# Altium schematic sheet Control_Wi-Fi_Module.SchDoc(Control_Wi-Fi_Module)
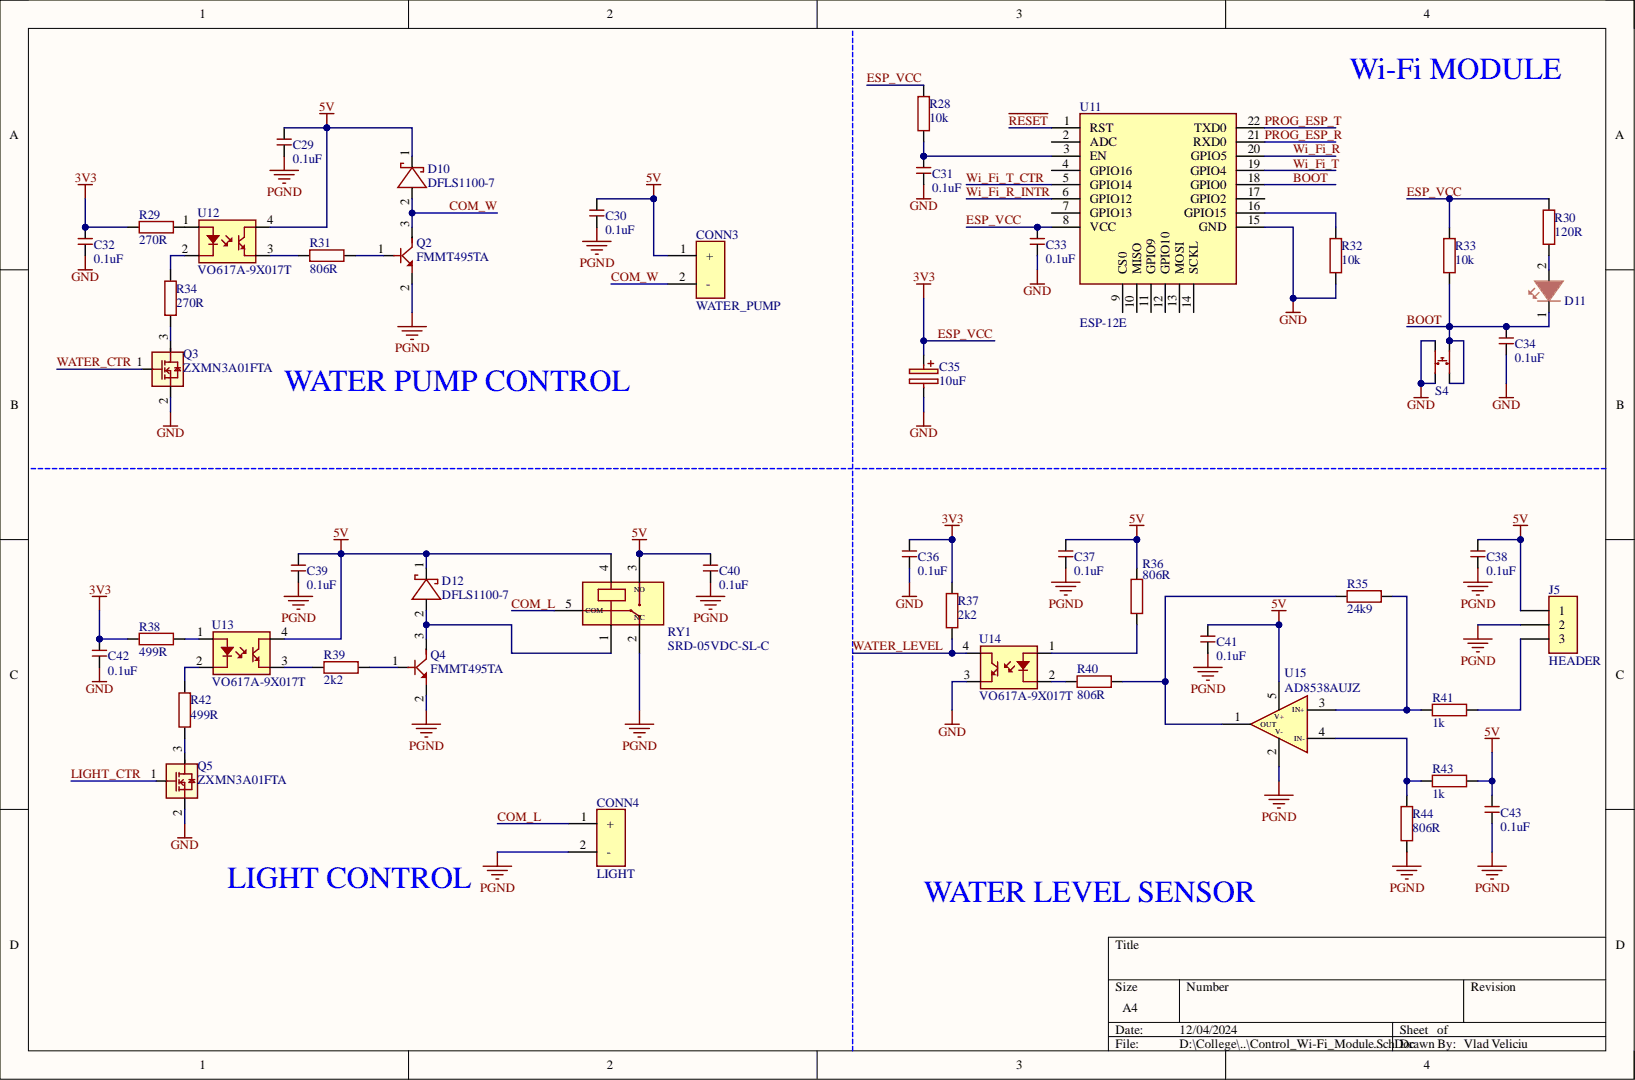
[diagram p0: Control_Wi-Fi_Module.SchDoc]

## Parts
C29  0.1uF  [C0603]
C30  0.1uF  [C0603]
C31  0.1uF  [C0603]
C32  0.1uF  [C0603]
C33  0.1uF  [C0603]
C34  0.1uF  [C0603]
C35  10uF  [CAPAE660X565N]
C36  0.1uF  [C0603]
C37  0.1uF  [C0603]
C38  0.1uF  [C0603]
C39  0.1uF  [C0603]
C40  0.1uF  [C0603]
C41  0.1uF  [C0603]
C42  0.1uF  [C0603]
C43  0.1uF  [C0603]
CONN3  WATER_PUMP  [1760510000]
CONN4  LIGHT  [1760510000]
D10  DFLS1100-7  [DFLS110]
D11  150060AS75000  [LED-0603]  (value not drawn)
D12  DFLS1100-7  [DFLS110]
J5  HEADER  [TMM-104-YY-XX-D-SM-3pins]
Q2  FMMT495TA  [SOT23-FMMT]
Q3  ZXMN3A01FTA  [SOT23]
Q4  FMMT495TA  [SOT23-FMMT]
Q5  ZXMN3A01FTA  [SOT23]
R28  10k  [R0805]
R29  270R  [R0805]
R30  120R  [R0805]
R31  806R  [R0805]
R32  10k  [R0805]
R33  10k  [R0805]
R34  270R  [R0805]
R35  24k9  [R0805]
R36  806R  [R0805]
R37  2k2  [R0805]
R38  499R  [R0805]
R39  2k2  [R0805]
R40  806R  [R0805]
R41  1k  [R0805]
R42  499R  [R0805]
R43  1k  [R0805]
R44  806R  [R0805]
RY1  SRD-05VDC-SL-C  [SRD-05VDC-SL-C]
S4  Pushbutton  [Pushbutton]  (value not drawn)
U11  ESP-12E  [ESP12E]
U12  VO617A-9X017T  [VO617A-9X017T]
U13  VO617A-9X017T  [VO617A-9X017T]
U14  VO617A-9X017T  [VO617A-9X017T]
U15  AD8538AUJZ  [SOT95P280X100-5N]

## Nets
5V: C29.2 C30.2 C37.2 C38.2 C39.2 C40.2 C41.2 C43.2 CONN3.1 D10.1 D12.1 J5.1 R36.2 R43.2 RY1.3(NO) RY1.4 U12.4 U13.4 U15.5(V+)
GND: C31.1 C32.1 C33.1 C34.1 C35.2 C36.1 C42.1 Q3.2 Q5.2 R32.1 S4.3 S4.4 U11.15(GND) U14.3
PGND: C29.1 C30.1 C37.1 C38.1 C39.1 C40.1 C41.1 C43.1 CONN4.2 J5.2 Q2.2 Q4.2 R44.1 RY1.2(NC) U15.2(V-)
BOOT: C34.2 D11.1 R33.1 S4.1 S4.2 U11.18(GPIO0)
COM_L: CONN4.1 RY1.5(COM)
COM_W: CONN3.2 D10.2 Q2.3
ESP_VCC: C32.2 C33.2 C35.1 C36.2 C42.2 R28.2 R29.1 R30.2 R33.2 R37.2 R38.1 U11.8(VCC)
LIGHT_CTR: Q5.1
PROG_ESP_R: U11.21(RXD0)
PROG_ESP_T: U11.22(TXD0)
~{RESET}: U11.1(RST)
WATER_CTR: Q3.1
WATER_LEVEL: R37.1 U14.4
Wi_Fi_R: U11.20(GPIO5)
Wi_Fi_R_INTR: U11.6(GPIO12)
Wi_Fi_T: U11.19(GPIO4)
Wi_Fi_T_CTR: U11.5(GPIO14)
NetC31_2: C31.2 R28.1 U11.3(EN)
NetD11_2: D11.2 R30.1
NetD12_2: D12.2 Q4.3 RY1.1
NetJ5_3: J5.3 R41.2
NetQ2_1: Q2.1 R31.2
NetQ3_3: Q3.3 R34.1
NetQ4_1: Q4.1 R39.2
NetQ5_3: Q5.3 R42.1
NetR29_2: R29.2 U12.1
NetR31_1: R31.1 U12.3
NetR32_2: R32.2 U11.16(GPIO15)
NetR34_2: R34.2 U12.2
NetR35_1: R35.1 R40.2 U15.1(OUT)
NetR35_2: R35.2 R41.1 U15.3(IN+)
NetR36_1: R36.1 U14.1
NetR38_2: R38.2 U13.1
NetR39_1: R39.1 U13.3
NetR40_1: R40.1 U14.2
NetR42_2: R42.2 U13.2
NetR43_1: R43.1 R44.2 U15.4(IN-)

Not connected: U11 2(ADC) 4(GPIO16) 7(GPIO13) 9(CS0) 10(MISO) 11(GPIO9) 12(GPIO10) 13(MOSI) 14(SCKL) 17(GPIO2)

## Library data of the parts
C29-C34 C36-C43 = C0603C104K8RAC: Ceramic Capacitor, Multilayer, Ceramic, 10V, 10% +Tol, 10% -Tol, X7R, 15% TC, 0.1uF, Surface Mount, 0603; Manufacturer: KEMET; Multilayer: Yes; Value: 100nF; Capacitor Type: Ceramic; Case/Package: 0603; Category: Ceramic Capacitors; Component Kind: Standard; ComponentLink1Description: https://datasheet.ciiva.com:443/pdfs/VipMasterIC/IC/KEME/KEME-S-A0008991654/KEME-S-A0008991654-1.pdf?src-supplier=IHS+Markit; ComponentLink1URL: https://datasheet.ciiva.com:443/pdfs/VipMasterIC/IC/KEME/KEME-S-A0008991654/KEME-S-A0008991654-1.pdf?src-supplier=IHS+Markit; ComponentLink2Description: http://www.mouser.com/ds/2/212/KEM_C1002_X7R_SMD-1102033.pdf?src-supplier=Mouser; ComponentLink2URL: http://www.mouser.com/ds/2/212/KEM_C1002_X7R_SMD-1102033.pdf?src-supplier=Mouser; Dielectric Material: Ceramic; Height: 0.8mm; JESD-609 Code: e3; Length: 1.6mm; Library Name: Schlib1.SchLib; Manufacturer Part Number: C0603C104K8RAC; Max Operating Temperature: 125°C; Min Operating Temperature: -55°C; Mounting Technology: Surface Mount; Package Shape: Rectangular; Package Style: SMTMeter; Packaging: Tape and Reel; Pins: 2; Pricing: 1=0.1, 10=0.061, 50=0.061, 100=0.031, 1000=0.022, 10000=0.015 (USD); Rated DC Voltage (URdc): 10V; Reach SVHC Compliant: Yes; RoHS: Yes; RoHS Compliant: Yes; Stock: 209299; Supplier: Mouser; Supplier Part Number: 80-C0603C104K8RAC; Temperature Characteristics Code: X7R; Terminal Finish: Nickel; Termination: Wraparound; Tolerance  (fill in as +-): 10%; Tolerance (fill in as +-): 10%; Width: 0.8mm
C35 = EXV106M050A9GAA: Aluminum Electrolytic Capacitor, Polarized, Aluminum (wet), 50V, 20% +Tol, 20% -Tol, 10uF, Surface Mount, 2626; Manufacturer: KEMET; Capacitor Type: Aluminium; ESR (Equivalent Series Resistance): 2.6R; Leakage Current: 5uA; Ripple Current: 98mA; Tan Delta: 0.12; Case/Package: 2626; Category: Aluminum Electrolytic Capacitors; Component Kind: Standard; ComponentLink1Description: https://datasheet.ciiva.com:443/pdfs/VipMasterIC/IC/KEME/KEME-S-A0009591402/KEME-S-A0009591402-1.pdf?src-supplier=IHS+Markit; ComponentLink1URL: https://datasheet.ciiva.com:443/pdfs/VipMasterIC/IC/KEME/KEME-S-A0009591402/KEME-S-A0009591402-1.pdf?src-supplier=IHS+Markit; ComponentLink2Description: https://datasheet.ciiva.com/22698/1500293-22698078.pdf?src-supplier=Element14; ComponentLink2URL: https://datasheet.ciiva.com/22698/1500293-22698078.pdf?src-supplier=Element14; Dielectric Material: Aluminium; Height: 5.4mm; Length: 7.8mm; Library Name: Schlib1.SchLib; Manufacturer Part Number: EXV106M050A9GAA; Max Operating Temperature: 105°C; Min Operating Temperature: -55°C; Mounting Technology: Surface Mount; Package Shape: Cylindrical; Package Style: SMTMeter; Packaging: Tape and Reel; Pins: 2; Polarity: Polarized; Pricing: 1=0.48, 10=0.255, 50=0.255, 100=0.165, 1000=0.139, 10000=0.112 (USD); Rated DC Voltage (URdc): 50V; Reach SVHC Compliant: Yes; RoHS: Yes; RoHS Compliant: Yes; Stock: 17264; Supplier: Mouser; Supplier Part Number: 80-EXV106M050A9GAA; Termination: Flat; Tolerance  (fill in as +-): 20%; Tolerance (fill in as +-): 20%; Value: 10uF; Width: 6.6mm
CONN3 CONN4 = BAT_TERM: Strip Terminal Block, 24A, 2.5mm2, 1 Row(s), 1 Deck(s); Manufacturer: Weidmuller; Additional Feature: STANDARD: UL 94V-0; Fastening Method: SOLDER; Number of Decks: 1; Number of Ways: 2; Safety Approval: UL,CSA; Voltage Rating: 600V; Wire/Cable Gauge: 14AWG; Category: Terminal Blocks; Component Kind: Standard; ComponentLink1Description: https://datasheet.ciiva.com:443/pdfs/VipMasterIC/IC/WEID/WEIDS07857/WEIDS07857-1.pdf?src-supplier=IHS+Markit; ComponentLink1URL: https://datasheet.ciiva.com:443/pdfs/VipMasterIC/IC/WEID/WEIDS07857/WEIDS07857-1.pdf?src-supplier=IHS+Markit; ComponentLink2Description: https://datasheet.ciiva.com/823/1760510000-823577.pdf?src-supplier=Digikey; ComponentLink2URL: https://datasheet.ciiva.com/823/1760510000-823577.pdf?src-supplier=Digikey; ComponentLink3Description: https://datasheet.ciiva.com/7143/1712606-7143944.pdf?src-supplier=Newark; ComponentLink3URL: https://datasheet.ciiva.com/7143/1712606-7143944.pdf?src-supplier=Newark; ComponentLink4Description: https://datasheet.ciiva.com/16071/1760510000-pm-5-08-02-90-3-5sn-bk-bx-en-pdf-154-16071541.pdf?src-supplier=Onlinecomponents.com; ComponentLink4URL: https://datasheet.ciiva.com/16071/1760510000-pm-5-08-02-90-3-5sn-bk-bx-en-pdf-154-16071541.pdf?src-supplier=Onlinecomponents.com; Current Rating: 24A; Library Name: Schlib1.SchLib; Manufacturer Part Number: 1760510000; Mounting Technology: Board; Number of Rows: 1; Pricing: 1=0.89, 10=0.554, 25=0.474, 50=0.4262, 100=0.4173, 250=0.35512, 500=0.33736, 1000=0.30185, 5000=0.23615 (USD); Reach SVHC Compliant: Yes; RoHS: Yes; RoHS Compliant: Yes; Stock: 49588; Supplier: Digikey; Supplier Part Number: 281-1882-ND; Terminal and Terminal Block Type: STRIP TERMINAL BLOCK; Wire Cross Section: 2.5mm2
D10 D12 = DFLS1100-7: Rectifier Diode, Schottky, 1 Phase, 1 Element, 1A, 100V V(RRM), Silicon; Manufacturer: Diodes; Case Connection: CATHODE; Forward Voltage: 770mV; Non-rep Pk Forward Current-Max: 40A; Number of Elements: 1; Package Body Material: Plastic; Qualification Status: Not Qualified; Time @ Peak Reflow Temperature-Max (s): 40s; Category: Rectifier Diodes; Component Kind: Standard; ComponentLink1Description: https://datasheet.ciiva.com:443/pdfs/VipMasterIC/IC/DIOD/DIOD-S-A0001756819/DIOD-S-A0001756819-1.pdf?src-supplier=IHS+Markit; ComponentLink1URL: https://datasheet.ciiva.com:443/pdfs/VipMasterIC/IC/DIOD/DIOD-S-A0001756819/DIOD-S-A0001756819-1.pdf?src-supplier=IHS+Markit; ComponentLink2Description: https://datasheet.ciiva.com/4715/ds30497-81105-4715653.pdf?src-supplier=Mouser; ComponentLink2URL: https://datasheet.ciiva.com/4715/ds30497-81105-4715653.pdf?src-supplier=Mouser; ComponentLink3Description: https://datasheet.ciiva.com/6932/ds30497-6932064.pdf?src-supplier=Newark; ComponentLink3URL: https://datasheet.ciiva.com/6932/ds30497-6932064.pdf?src-supplier=Newark; ComponentLink4Description: https://datasheet.ciiva.com/4245/2460ds30497-4245287.pdf?src-supplier=Arrow+Electronics; ComponentLink4URL: https://datasheet.ciiva.com/4245/2460ds30497-4245287.pdf?src-supplier=Arrow+Electronics; ComponentLink5Description: https://datasheet.ciiva.com/22695/1915475-22695803.pdf?src-supplier=Element14; ComponentLink5URL: https://datasheet.ciiva.com/22695/1915475-22695803.pdf?src-supplier=Element14; ComponentLink6Description: https://datasheet.ciiva.com/7964/ds30497-7964246.pdf?src-supplier=Element14; ComponentLink6URL: https://datasheet.ciiva.com/7964/ds30497-7964246.pdf?src-supplier=Element14; ComponentLink7Description: https://datasheet.ciiva.com/914/ds30497-914548.pdf?src-supplier=Digikey; ComponentLink7URL: https://datasheet.ciiva.com/914/ds30497-914548.pdf?src-supplier=Digikey; ComponentLink8Description: https://datasheet.ciiva.com/914/ds30497-914549.pdf?src-supplier=Digikey; ComponentLink8URL: https://datasheet.ciiva.com/914/ds30497-914549.pdf?src-supplier=Digikey; ComponentLink9Description: https://datasheet.ciiva.com/914/ds30497-914550.pdf?src-supplier=Digikey; ComponentLink9URL: https://datasheet.ciiva.com/914/ds30497-914550.pdf?src-supplier=Digikey; Diode Element Material: SILICON; Diode Type: RECTIFIER; JESD-30 Code: R-PDSO-F2; JESD-609 Code: e3; Library Name: Schlib1.SchLib; Manufacturer Part Number: DFLS1100-7; Max Operating Temperature: 175°C; Max Output current: 1A; Min Operating Temperature: -55°C; Moisture Sensitivity Level: 1; Package Shape: Rectangular; Package Style: SMALL OUTLINEMeter; Peak Reflow Temperature (Cel): 260°C; Pins: 2; Pricing: 1=0.4, 10=0.34, 100=0.2363, 500=0.18452, 1000=0.14998 (USD); Reach SVHC Compliant: No; Rep Pk Reverse Voltage-Max: 100V; RoHS: Yes; RoHS Compliant: Yes; Stock: 32532; Supplier: Digikey; Supplier Part Number: DFLS1100DICT-ND; Surface Mount: Yes; Technology: SCHOTTKY; Terminal Finish: Tin; Terminal Form: Flat; Terminal Position: DUAL
D11 = 150060AS75000: Single Color LED, Amber, Water Clear, 1.1mm; Manufacturer: Wurth Electronics; Category: LEDs; Component Kind: Standard; ComponentLink1Description: https://datasheet.ciiva.com:443/pdfs/VipMasterIC/IC/MIDC/MIDC-S-A0007768872/MIDC-S-A0007768872-1.pdf?src-supplier=IHS+Markit; ComponentLink1URL: https://datasheet.ciiva.com:443/pdfs/VipMasterIC/IC/MIDC/MIDC-S-A0007768872/MIDC-S-A0007768872-1.pdf?src-supplier=IHS+Markit; ComponentLink2Description: http://www.farnell.com/datasheets/2621639.pdf?src-supplier=Farnell; ComponentLink2URL: http://www.farnell.com/datasheets/2621639.pdf?src-supplier=Farnell; ComponentLink3Description: http://www.farnell.com/datasheets/2621639.pdf?src-supplier=Element14; ComponentLink3URL: http://www.farnell.com/datasheets/2621639.pdf?src-supplier=Element14; ComponentLink4Description: http://www.farnell.com/datasheets/2621639.pdf?src-supplier=Newark; ComponentLink4URL: http://www.farnell.com/datasheets/2621639.pdf?src-supplier=Newark; Library Name: Schlib1.SchLib; Manufacturer Part Number: 150060AS75000; Optoelectronic Device Type: SINGLE COLOR LED; Pricing: 1=0.15, 50=0.142, 100=0.122, 500=0.105 (USD); Reach SVHC Compliant: Yes; RoHS: Yes; RoHS Compliant: Yes; Stock: 19678; Supplier: Digikey; Supplier Part Number: 732-150060AS75000CT-ND
J5 = TMM-104-06-F-D-SM_3pins: Board Connector, 8 Contact(s), 2 Row(s), Male, Straight, 0.079 inch Pitch, Surface Mount Terminal, Black Insulator; Manufacturer: Samtec; Manufacturer Series: TMM-1; Body Breadth: 0.155inch; Body Depth: 0.109inch; Body Length: 0.316inch; Contact Pattern: RECTANGULAR; Contact Style: SQ PIN-SKT; DIN Conformance: No; Mounting Option 1: LOCKING; Number Of Connectors: 1; Number of Contacts: 8; Number Of PCB Rows: 2; Option: General Purpose; Plating Thickness: 3uin; Reference Standard: UL; Reliability: COMMERCIAL; Category: Headers and Wire Housings; Component Kind: Standard; ComponentLink1Description: https://datasheet.ciiva.com:443/pdfs/VipMasterIC/IC/SAMI/SAMI-S-A0001541321/SAMI-S-A0001541321-1.pdf?src-supplier=IHS+Markit; ComponentLink1URL: https://datasheet.ciiva.com:443/pdfs/VipMasterIC/IC/SAMI/SAMI-S-A0001541321/SAMI-S-A0001541321-1.pdf?src-supplier=IHS+Markit; ComponentLink2Description: https://datasheet.ciiva.com/10163/tmm-sm-10163085.pdf?src-supplier=Avnet; ComponentLink2URL: https://datasheet.ciiva.com/10163/tmm-sm-10163085.pdf?src-supplier=Avnet; Connector Type: BOARD CONNECTOR; Contact Finish - Mating: GOLD FLASH (3) OVER NICKEL (50); Contact Finish - Termination: MATTE TIN; Contact Gender: Male; Contact Material: Bronze; Filter Feature: No; Flammability Rating: UL94 V-0; IEC Conformance: No; Insulation Material: Polymer; JESD-609 Code: e3; Library Name: Schlib1.SchLib; Manufacturer Part Number: TMM-104-06-F-D-SM; Mating Contact Pitch: 0.079inch; Mating Contact Row Spacing: 0.079inch; MIL Conformance: No; Mixed Contacts: No; Mounting Style: STRAIGHT; Mounting Technology: Board; Number of Rows Loaded: 2; PCB Contact Pattern: RECTANGULAR; Pricing: 1=1.64, 10=1.424, 100=1.2726, 500=1.0605, 1000=0.909, 5000=0.80598, 10000=0.7878 (USD); Reach SVHC Compliant: Yes; RoHS: Yes; RoHS Compliant: Yes; Stock: 201; Supplier: Digikey; Supplier Part Number: TMM-104-06-F-D-SM-ND; Terminal Pitch: 2mm; Termination: SMD/SMT
Q2 Q4 = FMMT495TA: Small Signal Bipolar Transistor, 1A I(C), 150V V(BR)CEO, 1-Element, NPN, Silicon; Manufacturer: Diodes Zetex; DC Current Gain-Min (hFE): 100; Frequency: 100MHz; Number of Elements: 1; Package Body Material: Plastic; Power Dissipation-Max (Abs): 500mW; Qualification Status: Not Qualified; Time @ Peak Reflow Temperature-Max (s): 40s; Transistor Application: SWITCHING; Category: BJTs; Collector-emitter Voltage-Max: 150V; Component Kind: Standard; ComponentLink1Description: https://datasheet.ciiva.com:443/pdfs/VipMasterIC/IC/DIOD/DIOD-S-A0002833665/DIOD-S-A0002833682-1.pdf?src-supplier=IHS+Markit; ComponentLink1URL: https://datasheet.ciiva.com:443/pdfs/VipMasterIC/IC/DIOD/DIOD-S-A0002833665/DIOD-S-A0002833682-1.pdf?src-supplier=IHS+Markit; ComponentLink2Description: https://datasheet.ciiva.com/16392/fmmt495-16392681.pdf?src-supplier=Future+Electronics; ComponentLink2URL: https://datasheet.ciiva.com/16392/fmmt495-16392681.pdf?src-supplier=Future+Electronics; ComponentLink3Description: https://datasheet.ciiva.com/11201/fmmt495-11201932.pdf?src-supplier=Avnet; ComponentLink3URL: https://datasheet.ciiva.com/11201/fmmt495-11201932.pdf?src-supplier=Avnet; ComponentLink4Description: https://datasheet.ciiva.com/973/fmmt495-973916.pdf?src-supplier=Digikey; ComponentLink4URL: https://datasheet.ciiva.com/973/fmmt495-973916.pdf?src-supplier=Digikey; ComponentLink5Description: https://datasheet.ciiva.com/973/fmmt495-973917.pdf?src-supplier=Digikey; ComponentLink5URL: https://datasheet.ciiva.com/973/fmmt495-973917.pdf?src-supplier=Digikey; ComponentLink6Description: https://datasheet.ciiva.com/973/fmmt495-973918.pdf?src-supplier=Digikey; ComponentLink6URL: https://datasheet.ciiva.com/973/fmmt495-973918.pdf?src-supplier=Digikey; ComponentLink7Description: https://datasheet.ciiva.com/4723/fmmt495-4723559.pdf?src-supplier=Mouser; ComponentLink7URL: https://datasheet.ciiva.com/4723/fmmt495-4723559.pdf?src-supplier=Mouser; JESD-30 Code: R-PDSO-G3; JESD-609 Code: e3; Library Name: Schlib1.SchLib; Manufacturer Part Number: FMMT495TA; Max Collector Current: 1A; Max Operating Temperature: 150°C; Moisture Sensitivity Level: 1; Package Shape: Rectangular; Package Style: SMALL OUTLINEMeter; Peak Reflow Temperature (Cel): 260°C; Pins: 3; Polarity/Channel Type: NPN; Pricing: 1=0.38, 10=0.295, 100=0.1773, 500=0.16412, 1000=0.1116 (USD); Reach SVHC Compliant: Yes; RoHS: Yes; RoHS Compliant: Yes; Stock: 110105; Supplier: Digikey; Supplier Part Number: FMMT495CT-ND; Surface Mount: Yes; Terminal Finish: Tin; Terminal Form: Gull Wing; Terminal Position: DUAL; Transistor Element Material: SILICON
Q3 Q5 = ZXMN3A01FTA: Small Signal Field-Effect Transistor, 1.8A I(D), 30V, 1-Element, N-Channel, Silicon, Metal-oxide Semiconductor FET; Manufacturer: Diodes; Drain Current-Max (Abs) (ID): 1.81A; Drain-source On Resistance-Max: 120mR; Number of Elements: 1; Operating Mode: ENHANCEMENT MODE; Package Body Material: Plastic; Power Dissipation-Max (Abs): 806W; Qualification Status: Not Qualified; Time @ Peak Reflow Temperature-Max (s): 40s; Transistor Application: SWITCHING; Category: MOSFETs; Component Kind: Standard; ComponentLink1Description: https://datasheet.ciiva.com:443/pdfs/VipMasterIC/IC/DIOD/DIODS19930/DIODS19930-1.pdf?src-supplier=IHS+Markit; ComponentLink1URL: https://datasheet.ciiva.com:443/pdfs/VipMasterIC/IC/DIOD/DIODS19930/DIODS19930-1.pdf?src-supplier=IHS+Markit; ComponentLink2Description: https://datasheet.ciiva.com/4443/zxmn3a01f-4443120.pdf?src-supplier=Mouser; ComponentLink2URL: https://datasheet.ciiva.com/4443/zxmn3a01f-4443120.pdf?src-supplier=Mouser; ComponentLink3Description: https://datasheet.ciiva.com/935/zxmn3a01f-935010.pdf?src-supplier=Digikey; ComponentLink3URL: https://datasheet.ciiva.com/935/zxmn3a01f-935010.pdf?src-supplier=Digikey; ComponentLink4Description: https://datasheet.ciiva.com/935/zxmn3a01f-935011.pdf?src-supplier=Digikey; ComponentLink4URL: https://datasheet.ciiva.com/935/zxmn3a01f-935011.pdf?src-supplier=Digikey; ComponentLink5Description: https://datasheet.ciiva.com/935/zxmn3a01f-935012.pdf?src-supplier=Digikey; ComponentLink5URL: https://datasheet.ciiva.com/935/zxmn3a01f-935012.pdf?src-supplier=Digikey; ComponentLink6Description: https://datasheet.ciiva.com/11176/zxmn3a01f-11176629.pdf?src-supplier=Avnet; ComponentLink6URL: https://datasheet.ciiva.com/11176/zxmn3a01f-11176629.pdf?src-supplier=Avnet; ComponentLink7Description: https://datasheet.ciiva.com/11176/zxmn3a01f-11176630.pdf?src-supplier=Avnet; ComponentLink7URL: https://datasheet.ciiva.com/11176/zxmn3a01f-11176630.pdf?src-supplier=Avnet; ComponentLink8Description: https://datasheet.ciiva.com/5775/1643169-5775212.pdf?src-supplier=Farnell; ComponentLink8URL: https://datasheet.ciiva.com/5775/1643169-5775212.pdf?src-supplier=Farnell; ComponentLink9Description: https://datasheet.ciiva.com/5775/1643169-5775213.pdf?src-supplier=Farnell; ComponentLink9URL: https://datasheet.ciiva.com/5775/1643169-5775213.pdf?src-supplier=Farnell; ComponentLink10Description: https://datasheet.ciiva.com/16225/zxmn3a01f-16225209.pdf?src-supplier=Future+Electronics; ComponentLink10URL: https://datasheet.ciiva.com/16225/zxmn3a01f-16225209.pdf?src-supplier=Future+Electronics; ComponentLink11Description: https://datasheet.ciiva.com/8024/1643169-8024159.pdf?src-supplier=Element14; ComponentLink11URL: https://datasheet.ciiva.com/8024/1643169-8024159.pdf?src-supplier=Element14; Drain Current-Max (ID): 1.8A; DS Breakdown Voltage-Min: 30V; FET Technology: METAL-OXIDE SEMICONDUCTOR; JESD-30 Code: R-PDSO-G3; JESD-609 Code: e3; Library Name: Schlib1.SchLib; Manufacturer Part Number: ZXMN3A01FTA; Max Operating Temperature: 150°C; Moisture Sensitivity Level: 1; Package Shape: Rectangular; Package Style: SMALL OUTLINEMeter; Peak Reflow Temperature (Cel): 260°C; Pins: 3; Polarity/Channel Type: N-CHANNEL; Pricing: 1=0.46, 10=0.397, 100=0.2757, 500=0.21528, 1000=0.17498 (USD); Reach SVHC Compliant: Yes; RoHS: Yes; RoHS Compliant: Yes; Stock: 95699; Supplier: Digikey; Supplier Part Number: ZXMN3A01FCT-ND; Surface Mount: Yes; Terminal Finish: Tin; Terminal Form: Gull Wing; Terminal Position: DUAL; Transistor Element Material: SILICON
R28 R32 R33 = RT0805DRD0710KL: Fixed Resistor, Thin Film, 0.125W, 10000ohm, 150V, 0.5% +/-Tol, 25ppm/Cel, Surface Mount, 0805; Manufacturer: Yageo; Additional Feature: HIGH PRECISION; Package Height: 0.5mm; Package Length: 2mm; Package Width: 1.25mm; Power: 125mW; Rated Temperature: 70°C; Temperature Coefficient: 25ppm/°C; Value: 10kR; Case/Package: 0805; Category: Chip SMD Resistors; Component Kind: Standard; ComponentLink1Description: https://datasheet.ciiva.com:443/pdfs/VipMasterIC/IC/YAGO/YAGO-S-A0008510989/YAGO-S-A0008510989-1.pdf?src-supplier=IHS+Markit; ComponentLink1URL: https://datasheet.ciiva.com:443/pdfs/VipMasterIC/IC/YAGO/YAGO-S-A0008510989/YAGO-S-A0008510989-1.pdf?src-supplier=IHS+Markit; ComponentLink2Description: https://datasheet.ciiva.com/8891/pyu-rt-1-to-0-05-rohs-l-4-8891431.pdf?src-supplier=Avnet; ComponentLink2URL: https://datasheet.ciiva.com/8891/pyu-rt-1-to-0-05-rohs-l-4-8891431.pdf?src-supplier=Avnet; Construction: Chip; Library Name: Schlib1.SchLib; Manufacturer Part Number: RT0805DRD0710KL; Max Operating Temperature: 155°C; Min Operating Temperature: -55°C; Mounting Technology: Surface Mount; Package Style: SMTMeter; Packaging: Tape and Reel; Pins: 2; Pricing: 1=0.1, 10=0.059, 50=0.059, 100=0.048, 1000=0.033, 10000=0.021 (USD); Reach SVHC Compliant: Yes; Resistor Type: FIXED RESISTOR; RoHS: Yes; RoHS Compliant: Yes; Stock: 16743; Supplier: Mouser; Supplier Part Number: 603-RT0805DRD0710KL; Technology: THIN FILM; Termination: Wraparound; Tolerance: 0.5%; Working Voltage: 150V
R29 R34 = RT0805BRD07270RL: Fixed Resistor, Thin Film, 0.125W, 270ohm, 150V, 0.1% +/-Tol, 25ppm/Cel, Surface Mount, 0805; Manufacturer: Yageo; Additional Feature: HIGH PRECISION; Package Height: 0.5mm; Package Length: 2mm; Package Width: 1.25mm; Power: 125mW; Rated Temperature: 70°C; Temperature Coefficient: 25ppm/°C; Case/Package: 0805; Category: Chip SMD Resistors; Component Kind: Standard; ComponentLink1Description: https://datasheet.ciiva.com:443/pdfs/VipMasterIC/IC/YAGO/YAGO-S-A0008510989/YAGO-S-A0008510989-1.pdf?src-supplier=IHS+Markit; ComponentLink1URL: https://datasheet.ciiva.com:443/pdfs/VipMasterIC/IC/YAGO/YAGO-S-A0008510989/YAGO-S-A0008510989-1.pdf?src-supplier=IHS+Markit; ComponentLink2Description: https://datasheet.ciiva.com/46634/pyu-rt-1-to-0-05-rohs-l-5-46634938.pdf?src-supplier=Digikey; ComponentLink2URL: https://datasheet.ciiva.com/46634/pyu-rt-1-to-0-05-rohs-l-5-46634938.pdf?src-supplier=Digikey; ComponentLink3Description: https://datasheet.ciiva.com/2584/pyu-rt-1-to-0-05-rohs-l-4-2584891.pdf?src-supplier=Digikey; ComponentLink3URL: https://datasheet.ciiva.com/2584/pyu-rt-1-to-0-05-rohs-l-4-2584891.pdf?src-supplier=Digikey; ComponentLink4Description: https://datasheet.ciiva.com/12812/pyu-rt-1-to-0-05-rohs-l-4-12812585.pdf?src-supplier=Mouser; ComponentLink4URL: https://datasheet.ciiva.com/12812/pyu-rt-1-to-0-05-rohs-l-4-12812585.pdf?src-supplier=Mouser; Construction: Chip; Library Name: Schlib1.SchLib; Manufacturer Part Number: RT0805BRD07270RL; Max Operating Temperature: 155°C; Min Operating Temperature: -55°C; Mounting Technology: Surface Mount; Package Style: SMTMeter; Packaging: Tape and Reel; Pins: 2; Pricing: 1=0.15, 10=0.113, 50=0.089, 100=0.08, 1000=0.053, 10000=0.038 (USD); Reach SVHC Compliant: Yes; Resistor Type: FIXED RESISTOR; RoHS: Yes; RoHS Compliant: Yes; Stock: 5267; Supplier: Mouser; Supplier Part Number: 603-RT0805BRD07270RL; Technology: THIN FILM; Termination: Wraparound; Tolerance: 0.1%; Value: 270R; Working Voltage: 150V
R30 = RT0805DRD07120RL: Fixed Resistor, Thin Film, 0.125W, 120ohm, 150V, 0.5% +/-Tol, 25ppm/Cel, Surface Mount, 0805; Manufacturer: Yageo; Additional Feature: HIGH PRECISION; Package Height: 0.5mm; Package Length: 2mm; Package Width: 1.25mm; Power: 125mW; Rated Temperature: 70°C; Temperature Coefficient: 25ppm/°C; Case/Package: 0805; Category: Chip SMD Resistors; Component Kind: Standard; ComponentLink1Description: https://datasheet.ciiva.com:443/pdfs/VipMasterIC/IC/YAGO/YAGO-S-A0008510989/YAGO-S-A0008510989-1.pdf?src-supplier=IHS+Markit; ComponentLink1URL: https://datasheet.ciiva.com:443/pdfs/VipMasterIC/IC/YAGO/YAGO-S-A0008510989/YAGO-S-A0008510989-1.pdf?src-supplier=IHS+Markit; ComponentLink2Description: http://www.yageo.com/documents/recent/PYu-RT_1-to-0.01_RoHS_L_9.pdf?src-supplier=Digikey; ComponentLink2URL: http://www.yageo.com/documents/recent/PYu-RT_1-to-0.01_RoHS_L_9.pdf?src-supplier=Digikey; Construction: Chip; Library Name: Schlib1.SchLib; Manufacturer Part Number: RT0805DRD07120RL; Max Operating Temperature: 155°C; Min Operating Temperature: -55°C; Mounting Technology: Surface Mount; Package Style: SMTMeter; Packaging: Tape and Reel; Pins: 2; Pricing: 1=0.1, 10=0.076, 50=0.0606, 100=0.0545, 500=0.0418, 1000=0.03701 (USD); Reach SVHC Compliant: Yes; Resistor Type: FIXED RESISTOR; RoHS: Yes; RoHS Compliant: Yes; Stock: 4920; Supplier: Digikey; Supplier Part Number: 13-RT0805DRD07120RLCT-ND; Technology: THIN FILM; Termination: Wraparound; Tolerance: 0.5%; Value: 120R; Working Voltage: 150V
R31 R36 R40 R44 = RT0805BRD07806RL: Fixed Resistor, Thin Film, 0.125W, 806ohm, 150V, 0.1% +/-Tol, 25ppm/Cel, Surface Mount, 0805; Manufacturer: Yageo; Additional Feature: HIGH PRECISION; Package Height: 0.5mm; Package Length: 2mm; Package Width: 1.25mm; Power: 125mW; Rated Temperature: 70°C; Temperature Coefficient: 25ppm/°C; Case/Package: 0805; Category: Chip SMD Resistors; Component Kind: Standard; ComponentLink1Description: https://datasheet.ciiva.com:443/pdfs/VipMasterIC/IC/YAGO/YAGO-S-A0008510989/YAGO-S-A0008510989-1.pdf?src-supplier=IHS+Markit; ComponentLink1URL: https://datasheet.ciiva.com:443/pdfs/VipMasterIC/IC/YAGO/YAGO-S-A0008510989/YAGO-S-A0008510989-1.pdf?src-supplier=IHS+Markit; ComponentLink2Description: https://datasheet.ciiva.com/2585/pyu-rt-1-to-0-05-rohs-l-5-2585181.pdf?src-supplier=Digikey; ComponentLink2URL: https://datasheet.ciiva.com/2585/pyu-rt-1-to-0-05-rohs-l-5-2585181.pdf?src-supplier=Digikey; Construction: Chip; Library Name: Schlib1.SchLib; Manufacturer Part Number: RT0805BRD07806RL; Max Operating Temperature: 155°C; Min Operating Temperature: -55°C; Mounting Technology: Surface Mount; Package Style: SMTMeter; Packaging: Tape and Reel; Pins: 2; Pricing: 1=0.16, 10=0.12, 50=0.095, 100=0.085, 1000=0.057, 10000=0.038 (USD); Reach SVHC Compliant: Yes; Resistor Type: FIXED RESISTOR; RoHS: Yes; RoHS Compliant: Yes; Stock: 2476; Supplier: Mouser; Supplier Part Number: 603-RT0805BRD07806RL; Technology: THIN FILM; Termination: Wraparound; Tolerance: 0.1%; Value: 806R; Working Voltage: 150V
R35 = RT0805BRD0724K9L: Fixed Resistor, Thin Film, 0.125W, 24900ohm, 150V, 0.1% +/-Tol, 25ppm/Cel, Surface Mount, 0805; Manufacturer: Yageo; Additional Feature: HIGH PRECISION; Package Height: 0.5mm; Package Length: 2mm; Package Width: 1.25mm; Power: 125mW; Rated Temperature: 70°C; Temperature Coefficient: 25ppm/°C; Value: 24.9kR; Case/Package: 0805; Category: Chip SMD Resistors; Component Kind: Standard; ComponentLink1Description: https://datasheet.ciiva.com:443/pdfs/VipMasterIC/IC/YAGO/YAGO-S-A0008510989/YAGO-S-A0008510989-1.pdf?src-supplier=IHS+Markit; ComponentLink1URL: https://datasheet.ciiva.com:443/pdfs/VipMasterIC/IC/YAGO/YAGO-S-A0008510989/YAGO-S-A0008510989-1.pdf?src-supplier=IHS+Markit; ComponentLink2Description: https://datasheet.ciiva.com/2584/pyu-rt-1-to-0-05-rohs-l-4-2584873.pdf?src-supplier=Digikey; ComponentLink2URL: https://datasheet.ciiva.com/2584/pyu-rt-1-to-0-05-rohs-l-4-2584873.pdf?src-supplier=Digikey; ComponentLink3Description: https://datasheet.ciiva.com/8890/pyu-rt-1-to-0-05-rohs-l-4-8890022.pdf?src-supplier=Avnet; ComponentLink3URL: https://datasheet.ciiva.com/8890/pyu-rt-1-to-0-05-rohs-l-4-8890022.pdf?src-supplier=Avnet; ComponentLink4Description: https://datasheet.ciiva.com/46634/pyu-rt-1-to-0-05-rohs-l-5-46634875.pdf?src-supplier=Digikey; ComponentLink4URL: https://datasheet.ciiva.com/46634/pyu-rt-1-to-0-05-rohs-l-5-46634875.pdf?src-supplier=Digikey; Construction: Chip; Library Name: Schlib1.SchLib; Manufacturer Part Number: RT0805BRD0724K9L; Max Operating Temperature: 155°C; Min Operating Temperature: -55°C; Mounting Technology: Surface Mount; Package Style: SMTMeter; Packaging: Tape and Reel; Pins: 2; Pricing: 1=0.16, 10=0.116, 50=0.095, 100=0.085, 1000=0.057, 10000=0.038 (USD); Reach SVHC Compliant: Yes; Resistor Type: FIXED RESISTOR; RoHS: Yes; RoHS Compliant: Yes; Stock: 8795; Supplier: Mouser; Supplier Part Number: 603-RT0805BRD0724K9L; Technology: THIN FILM; Termination: Wraparound; Tolerance: 0.1%; Working Voltage: 150V
R37 R39 = RC0805DR-072K2L: Fixed Resistor, Metal Glaze/thick Film, 0.125W, 2200ohm, 150V, 0.5% +/-Tol, 100ppm/Cel, Surface Mount, 0805; Manufacturer: Yageo; Additional Feature: LASER TRIMMABLE; Package Height: 0.5mm; Package Length: 2mm; Package Width: 1.25mm; Power: 125mW; Rated Temperature: 70°C; Temperature Coefficient: 100ppm/°C; Value: 2.2kR; Case/Package: 0805; Category: Chip SMD Resistors; Component Kind: Standard; ComponentLink1Description: https://datasheet.ciiva.com:443/pdfs/VipMasterIC/IC/YAGO/YAGO-S-A0008145938/YAGO-S-A0008145938-1.pdf?src-supplier=IHS+Markit; ComponentLink1URL: https://datasheet.ciiva.com:443/pdfs/VipMasterIC/IC/YAGO/YAGO-S-A0008145938/YAGO-S-A0008145938-1.pdf?src-supplier=IHS+Markit; ComponentLink2Description: http://www.yageo.com.tw/exep/pages/download/literatures/PYu-R_INT-thick_7.pdf?src-supplier=Digikey; ComponentLink2URL: http://www.yageo.com.tw/exep/pages/download/literatures/PYu-R_INT-thick_7.pdf?src-supplier=Digikey; Construction: Chip; JESD-609 Code: e3; Library Name: Schlib1.SchLib; Manufacturer Part Number: RC0805DR-072K2L; Max Operating Temperature: 155°C; Min Operating Temperature: -55°C; Mounting Technology: Surface Mount; Package Shape: Rectangular; Package Style: SMTMeter; Packaging: Tape and Reel; Pins: 2; Pricing: 1=0.13, 10=0.063, 50=0.0414, 100=0.0352, 500=0.02476, 1000=0.02156 (USD); Reach SVHC Compliant: Yes; Resistor Type: FIXED RESISTOR; RoHS: Yes; RoHS Compliant: Yes; Stock: 19275; Supplier: Digikey; Supplier Part Number: 13-RC0805DR-072K2LCT-ND; Technology: METAL GLAZE/THICK FILM; Terminal Finish: Nickel; Termination: Wraparound; Tolerance: 0.5%; Working Voltage: 150V
R38 R42 = RT0805DRE07499RL: Fixed Resistor, Thin Film, 0.125W, 499ohm, 150V, 0.5% +/-Tol, 50ppm/Cel, Surface Mount, 0805; Manufacturer: Yageo; Additional Feature: HIGH PRECISION; Package Height: 0.5mm; Package Length: 2mm; Package Width: 1.25mm; Power: 125mW; Rated Temperature: 70°C; Temperature Coefficient: 50ppm/°C; Case/Package: 0805; Category: Chip SMD Resistors; Component Kind: Standard; ComponentLink1Description: https://datasheet.ciiva.com:443/pdfs/VipMasterIC/IC/YAGO/YAGO-S-A0008510989/YAGO-S-A0008510989-1.pdf?src-supplier=IHS+Markit; ComponentLink1URL: https://datasheet.ciiva.com:443/pdfs/VipMasterIC/IC/YAGO/YAGO-S-A0008510989/YAGO-S-A0008510989-1.pdf?src-supplier=IHS+Markit; ComponentLink2Description: https://datasheet.ciiva.com/2569/pyu-rt-1-to-0-05-rohs-l-5-2569517.pdf?src-supplier=Digikey; ComponentLink2URL: https://datasheet.ciiva.com/2569/pyu-rt-1-to-0-05-rohs-l-5-2569517.pdf?src-supplier=Digikey; Construction: Chip; Library Name: Schlib1.SchLib; Manufacturer Part Number: RT0805DRE07499RL; Max Operating Temperature: 155°C; Min Operating Temperature: -55°C; Mounting Technology: Surface Mount; Package Style: SMTMeter; Packaging: Tape and Reel; Pins: 2; Pricing: 1=0.1, 10=0.047, 50=0.0392, 100=0.0357, 500=0.02826, 1000=0.02534 (USD); Reach SVHC Compliant: Yes; Resistor Type: FIXED RESISTOR; RoHS: Yes; RoHS Compliant: Yes; Stock: 13062; Supplier: Digikey; Supplier Part Number: 311-2868-1-ND; Technology: THIN FILM; Termination: Wraparound; Tolerance: 0.5%; Value: 499R; Working Voltage: 150V
R41 R43 = RT0805BRD071KL: Fixed Resistor, Thin Film, 0.125W, 1000ohm, 150V, 0.1% +/-Tol, 25ppm/Cel, Surface Mount, 0805; Manufacturer: Yageo; Additional Feature: HIGH PRECISION; Package Height: 0.5mm; Package Length: 2mm; Package Width: 1.25mm; Power: 125mW; Rated Temperature: 70°C; Temperature Coefficient: 25ppm/°C; Value: 1kR; Case/Package: 0805; Category: Chip SMD Resistors; Component Kind: Standard; ComponentLink1Description: https://datasheet.ciiva.com:443/pdfs/VipMasterIC/IC/YAGO/YAGO-S-A0008510989/YAGO-S-A0008510989-1.pdf?src-supplier=IHS+Markit; ComponentLink1URL: https://datasheet.ciiva.com:443/pdfs/VipMasterIC/IC/YAGO/YAGO-S-A0008510989/YAGO-S-A0008510989-1.pdf?src-supplier=IHS+Markit; ComponentLink2Description: https://datasheet.ciiva.com/46635/pyu-rt-1-to-0-05-rohs-l-5-46635037.pdf?src-supplier=Digikey; ComponentLink2URL: https://datasheet.ciiva.com/46635/pyu-rt-1-to-0-05-rohs-l-5-46635037.pdf?src-supplier=Digikey; ComponentLink3Description: https://datasheet.ciiva.com/12836/pyu-rt-1-to-0-05-rohs-l-4-12836655.pdf?src-supplier=Mouser; ComponentLink3URL: https://datasheet.ciiva.com/12836/pyu-rt-1-to-0-05-rohs-l-4-12836655.pdf?src-supplier=Mouser; ComponentLink4Description: https://datasheet.ciiva.com/8896/pyu-rt-1-to-0-05-rohs-l-4-8896157.pdf?src-supplier=Avnet; ComponentLink4URL: https://datasheet.ciiva.com/8896/pyu-rt-1-to-0-05-rohs-l-4-8896157.pdf?src-supplier=Avnet; ComponentLink5Description: https://datasheet.ciiva.com/2584/pyu-rt-1-to-0-05-rohs-l-4-2584828.pdf?src-supplier=Digikey; ComponentLink5URL: https://datasheet.ciiva.com/2584/pyu-rt-1-to-0-05-rohs-l-4-2584828.pdf?src-supplier=Digikey; Construction: Chip; Library Name: Schlib1.SchLib; Manufacturer Part Number: RT0805BRD071KL; Max Operating Temperature: 155°C; Min Operating Temperature: -55°C; Mounting Technology: Surface Mount; Package Style: SMTMeter; Packaging: Tape and Reel; Pins: 2; Pricing: 1=0.16, 10=0.12, 50=0.0946, 100=0.0846, 500=0.06418, 1000=0.05663 (USD); Reach SVHC Compliant: Yes; Resistor Type: FIXED RESISTOR; RoHS: Yes; RoHS Compliant: Yes; Stock: 107171; Supplier: Digikey; Supplier Part Number: YAG1820CT-ND; Technology: THIN FILM; Termination: Wraparound; Tolerance: 0.1%; Working Voltage: 150V
RY1 = Relay: Releu 5 pini aliniabili pe PCB    Voltaj comutare : DC 5V    Capacitate sarcina max: 10A 250VAC/125VAC/30VDC/28VDC; Component Kind: Standard; Distributor: Sigmanortec; Library Name: Schlib1.SchLib; Price (RON): 4.88
S4 = Pushbutton: BUTON MINI 6X6X5, 4 PINI; Component Kind: Standard; Library Name: Schlib1.SchLib; Price: 0.36 RON; Supplier: Sigmanortec
U11 = ESP-12E: MODUL WIFI ESP8266, ESP12-E, A.I., ORIGINAL; Component Kind: Standard; Library Name: Schlib1.SchLib; Price (RON): 23.8; Supplier: Sigmanortec
U12-U14 = VO617A-9X017T: Transistor Output Optocoupler, 1-Element, 5300V Isolation; Manufacturer: Vishay; Coll-emtr Bkdn Voltage-Min: 80V; Current Transfer Ratio-Nom: 200%; Dark Current-Max: 100nA; Forward Current: 60mA; Isolation Voltage-Max: 5.3kV; Number of Elements: 1; Category: Optocouplers; Component Kind: Standard; ComponentLink1Description: https://datasheet.ciiva.com:443/pdfs/VipMasterIC/IC/VISH/VISH-S-A0004680336/VISH-S-A0004680336-1.pdf?src-supplier=IHS+Markit; ComponentLink1URL: https://datasheet.ciiva.com:443/pdfs/VipMasterIC/IC/VISH/VISH-S-A0004680336/VISH-S-A0004680336-1.pdf?src-supplier=IHS+Markit; JESD-609 Code: e3; Library Name: Schlib1.SchLib; Manufacturer Part Number: VO617A-9X017T; Max Operating Temperature: 110°C; Min Operating Temperature: -55°C; Optoelectronic Device Type: TRANSISTOR OUTPUT OPTOCOUPLER; Pricing: 1=0.43, 10=0.263, 100=0.151 (USD); RoHS: Yes; RoHS Compliant: Yes; Stock: 79924; Supplier: Digikey; Supplier Part Number: 751-VO617A-9X017TCT-ND; Terminal Finish: Tin
U15 = AD8538AUJZ-REEL7: Operational Amplifier, 1 Func, 30uV Offset-Max, CMOS, PDSO5; Manufacturer: Analog Devices; Architecture: VOLTAGE-FEEDBACK; Average Bias Current-Max (IIB): 100pA; Bias Current-Max (IIB) @25C: 25pA; Channels: 1; Common-mode Reject Ratio-Nom: 135dB; Frequency Compensation: YES; Max Supply Current: 215uA; Neg Supply Voltage Limit-Max: 0V; Nominal Supply Voltage: 5V; Package Body Material: Plastic; Package Equivalence Code: TSOP5/6,.11,37; Power: No; Power Supplies: +-1.35/+-2.5/2.7/5V; Qualification Status: Not Qualified; Slew Rate: 0.4V/µs; Supply Voltage Limit-Max: 6V; Time @ Peak Reflow Temperature-Max (s): 40s; Unity Gain BW-Nom: 430kHz; Voltage Gain-Min: 178000; Amplifier Type: OPERATIONAL AMPLIFIER; Case/Package: VSSOP; Category: Amplifiers - Op Amps, Buffer, Instrumentation; Component Kind: Standard; ComponentLink1Description: https://datasheet.ciiva.com:443/pdfs/VipMasterIC/IC/ANDI/ANDIS13894/ANDIS13894-1.pdf?src-supplier=IHS+Markit; ComponentLink1URL: https://datasheet.ciiva.com:443/pdfs/VipMasterIC/IC/ANDI/ANDIS13894/ANDIS13894-1.pdf?src-supplier=IHS+Markit; ComponentLink2Description: https://datasheet.ciiva.com/15374/ad8538-8539-243268-15374656.pdf?src-supplier=Mouser; ComponentLink2URL: https://datasheet.ciiva.com/15374/ad8538-8539-243268-15374656.pdf?src-supplier=Mouser; ComponentLink3Description: https://datasheet.ciiva.com/2974/ad8538-8539-2974672.pdf?src-supplier=Avnet; ComponentLink3URL: https://datasheet.ciiva.com/2974/ad8538-8539-2974672.pdf?src-supplier=Avnet; ComponentLink4Description: https://datasheet.ciiva.com/1793/ad8538-8539-1793940.pdf?src-supplier=Digikey; ComponentLink4URL: https://datasheet.ciiva.com/1793/ad8538-8539-1793940.pdf?src-supplier=Digikey; ComponentLink5Description: https://datasheet.ciiva.com/1793/ad8538-8539-1793941.pdf?src-supplier=Digikey; ComponentLink5URL: https://datasheet.ciiva.com/1793/ad8538-8539-1793941.pdf?src-supplier=Digikey; ComponentLink6Description: https://datasheet.ciiva.com/1793/ad8538-8539-1793942.pdf?src-supplier=Digikey; ComponentLink6URL: https://datasheet.ciiva.com/1793/ad8538-8539-1793942.pdf?src-supplier=Digikey; ComponentLink7Description: https://datasheet.ciiva.com/2973/ad8538-8539-2973062.pdf?src-supplier=Avnet; ComponentLink7URL: https://datasheet.ciiva.com/2973/ad8538-8539-2973062.pdf?src-supplier=Avnet; Height - Seated (Max): 1mm; Input Offset Voltage-Max: 30uV; JESD-30 Code: R-PDSO-G5; JESD-609 Code: e3; Length: 2.9mm; Library Name: Schlib1.SchLib; Low-Bias: Yes; Low-Offset: Yes; Manufacturer Part Number: AD8538AUJZ-REEL7; Max Operating Temperature: 125°C; Micropower: Yes; Min Operating Temperature: -40°C; Moisture Sensitivity Level: 1; Neg Supply Voltage-Nom (Vsup): 0V; Package Shape: Rectangular; Package Style: SMALL OUTLINE, VERY THIN PROFILE, SHRINK PITCHMeter; Packaging: Tape and Reel; Peak Reflow Temperature (Cel): 260°C; Pins: 5; Pricing: 1=1.65, 10=1.42, 50=1.42, 100=1.25, 1000=0.916, 10000=0.84 (USD); Programmable Power: No; Reach SVHC Compliant: Yes; RoHS: Yes; RoHS Compliant: Yes; Stock: 130448; Supplier: Mouser; Supplier Part Number: 584-AD8538AUJZ-R7; Surface Mount: Yes; Technology: CMOS; Temperature Grade: AUTOMOTIVE; Terminal Finish: Tin; Terminal Form: Gull Wing; Terminal Pitch: 0.95mm; Terminal Position: DUAL; Wideband: No; Width: 1.6mm
